# Altium schematic sheet vape.SchDoc
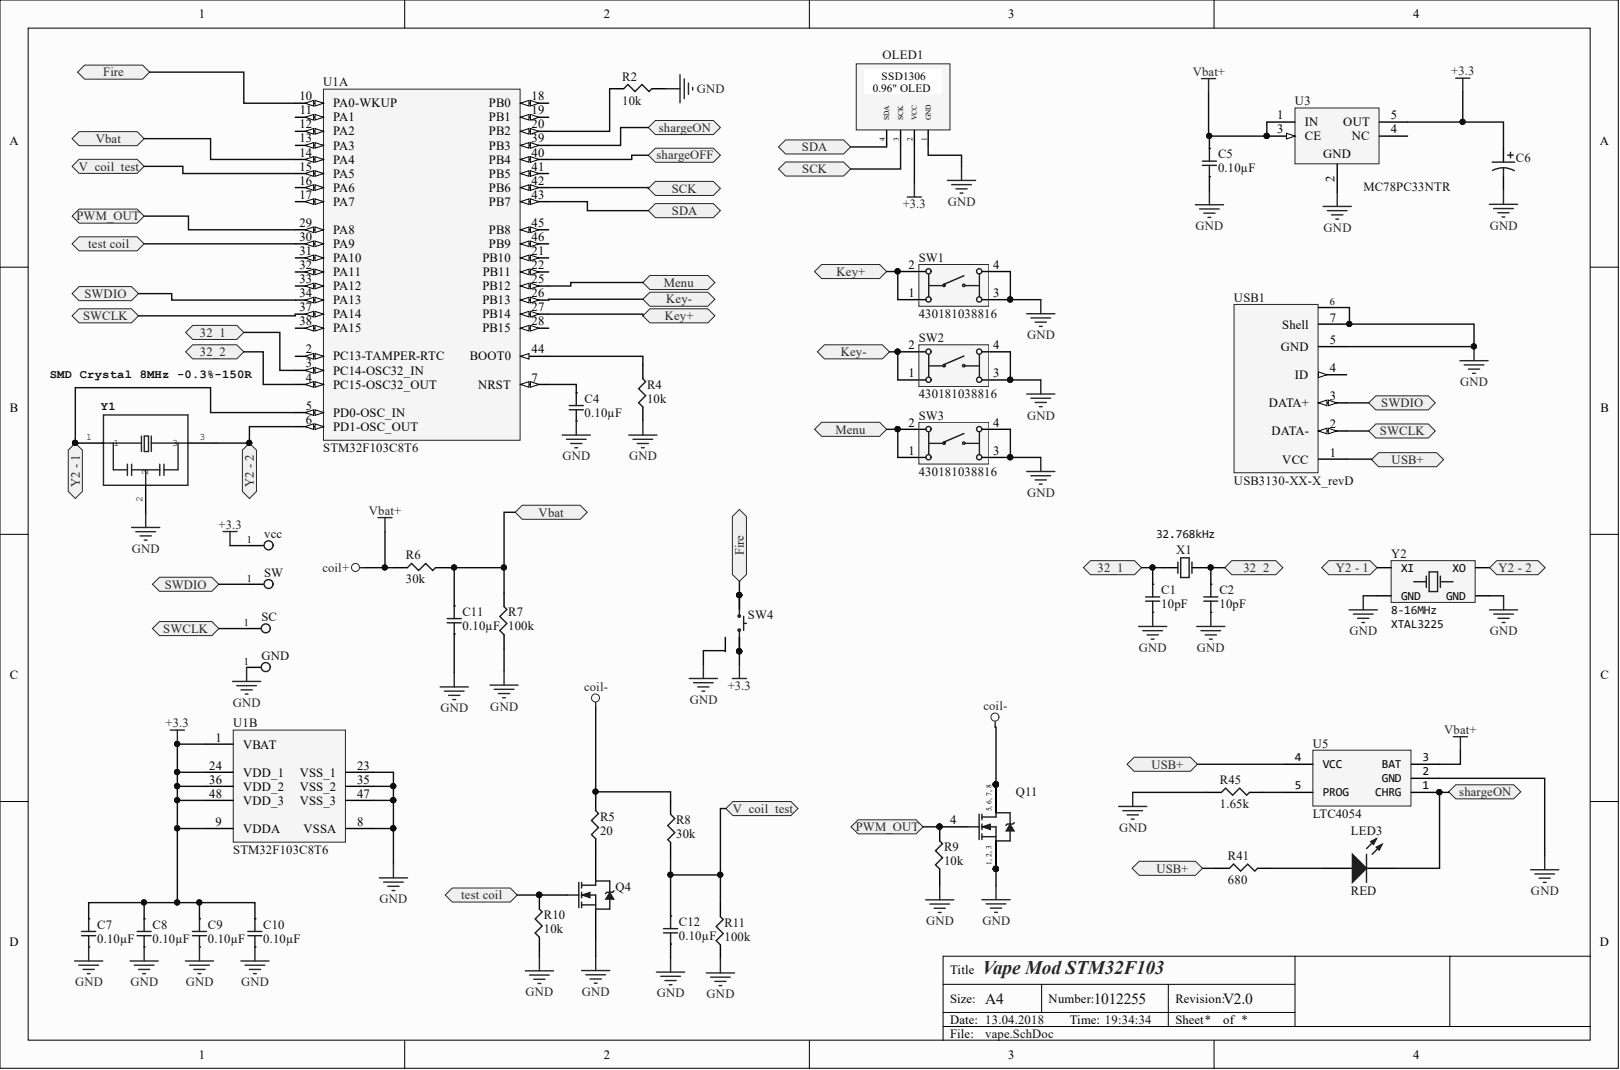
[diagram p0: vape.SchDoc]

## Parts
C1  10pF  [SMD-0402C]
C2  10pF  [SMD-0402C]
C4  0.10µF  [SMD-0805C]
C5  0.10µF  [SMD-0805C]
C6  49BC105B010M  [49BC-B]  (value not drawn)
C7  0.10µF  [SMD-0805C]
C8  0.10µF  [SMD-0805C]
C9  0.10µF  [SMD-0805C]
C10  0.10µF  [SMD-0805C]
C11  0.10µF  [SMD-0805C]
C12  0.10µF  [SMD-0805C]
GND  P2  [Pin]  (value not drawn)
LED3  RED  [0805_A]
OLED1  OLED  [SSD1306]
Q4  IRLML2803  [SOT-23_N]  (value not drawn)
Q11  IRF7413  [SO-8_N]  (value not drawn)
R2  10k  [SMD-0603-RES]
R4  10k  [SMD-0603-RES]
R5  20  [SMD-1206-RES]
R6  30k  [SMD-0603-RES]
R7  100k  [SMD-0603-RES]
R8  30k  [SMD-0603-RES]
R9  10k  [SMD-0603-RES]
R10  10k  [SMD-0603-RES]
R11  100k  [SMD-0603-RES]
R41  680  [SMD-0603-RES]
R45  1.65k  [SMD-0603-RES]
SC  P2  [Pin]  (value not drawn)
SW  P1  [Pin]  (value not drawn)
SW1  430181038816  [430181038816]
SW2  430181038816  [430181038816]
SW3  430181038816  [430181038816]
SW4  SPST-NO  [TE FSM1LPATR]  (value not drawn)
U1  STM32F103C8T6  [STM-LQFP48_N]  (parts A,B)
U3  MC78PC33NTR  [1212-01]
U5  LTC4054  [SOT23-5]
USB1  USB3130-XX-X_revD  [USB3130-XX-X_revD]
vcc  P2  [Pin]  (value not drawn)
X1  32.768kHz  [XT_3215_2]
Y1  SMD Crystal 8MHz -0.3%-150R  [OSC_CSTCE8M00G55-R0]
Y2  8-16MHz  Type: XTAL3225  [XT_3225_4]

## Ports
32_1, 32_2, FIRE, KEY+, KEY-, MENU, PWM_OUT, SCK, SDA, SHARGEOFF, SHARGEON, SWCLK, SWDIO, TEST COIL, USB+, V_COIL_TEST, VBAT, Y2 - 1, Y2 - 2

## Nets
+3.3: C6.1 C7.1 C8.1 C9.1 C10.1 OLED1.2(VCC) SW4.3 SW4.4 U1.1(VBAT) U1.9(VDDA) U1.24(VDD_1) U1.36(VDD_2) U1.48(VDD_3) U3.5(OUT) vcc.1
COIL+: C5.1 R6.1 U3.1(IN) U3.3(CE) U5.3(BAT)
COIL-: Q11.5 Q11.6 Q11.7 Q11.8 R5.2 R8.1
GND: C1.2 C2.2 C4.2 C5.2 C6.2 C7.2 C8.2 C9.2 C10.2 C11.2 C12.2 GND.1 OLED1.1(GND) Q4.2 Q11.1 Q11.2 Q11.3 R2.2 R4.1 R7.1 R9.1 R10.1 R11.1 R45.1 SW1.3 SW1.4 SW2.3 SW2.4 SW3.3 SW3.4 SW4.5 U1.8(VSSA) U1.23(VSS_1) U1.35(VSS_2) U1.47(VSS_3) U3.2 U5.2(GND) USB1.5(GND) USB1.6 USB1.7(Shell) Y1.2 Y2.2(GND) Y2.4(GND)
32_1: C1.1 U1.3(PC14-OSC32_IN) X1.1 ; port 32_1
32_2: C2.1 U1.4(PC15-OSC32_OUT) X1.2 ; port 32_2
PWM_OUT: Q11.4 R9.2 U1.29(PA8) ; port PWM_OUT
SCK: OLED1.3(SCK) U1.42(PB6) ; port SCK
SDA: OLED1.4(SDA) U1.43(PB7) ; port SDA
SWCLK: SC.1 U1.37(PA14) USB1.2(DATA-) ; port SWCLK
SWDIO: SW.1 U1.34(PA13) USB1.3(DATA+) ; port SWDIO
USB+: R41.1 U5.4(VCC) USB1.1(VCC) ; port USB+
Y2 - 1: U1.5(PD0-OSC_IN) Y1.1 Y2.1(XI) ; port Y2 - 1
Y2 - 2: U1.6(PD1-OSC_OUT) Y1.3 Y2.3(XO) ; port Y2 - 2
NetC4_1: C4.1 U1.7(NRST)
NetC11_1: C11.1 R6.2 R7.2 U1.14(PA4) ; port VBAT
NetC12_1: C12.1 R8.2 R11.2 U1.15(PA5) ; port V_COIL_TEST
NetLED3_1: LED3.1 U1.39(PB3) U5.1(CHRG) ; port SHARGEON
NetLED3_2: LED3.2 R41.2
NetQ4_1: Q4.1 R10.2 U1.30(PA9) ; port TEST COIL
NetQ4_3: Q4.3 R5.1
NetR2_1: R2.1 U1.20(PB2)
NetR4_2: R4.2 U1.44(BOOT0)
NetR45_2: R45.2 U5.5(PROG)
NetSW1_1: SW1.1 SW1.2 U1.27(PB14)
NetSW2_1: SW2.1 SW2.2 U1.26(PB13)
NetSW3_1: SW3.1 SW3.2 U1.25(PB12) ; port MENU
NetSW4_1: SW4.1 SW4.2 U1.10(PA0-WKUP) ; port FIRE
NetU1_40: U1.40(PB4) ; port SHARGEOFF

Not connected: U1 2(PC13-TAMPER-RTC) 11(PA1) 12(PA2) 13(PA3) 16(PA6) 17(PA7) 18(PB0) 19(PB1) 21(PB10) 22(PB11) 28(PB15) 31(PA10) 32(PA11) 33(PA12) 38(PA15) 41(PB5) 45(PB8) 46(PB9); U3 4(NC); USB1 4(ID)

## Library data of the parts
C1 C2 = GCM1555C1H100JA16D: CAP CER 10PF 50V NP0 0402; Manufacturer: Murata Electronics North America; @ qty: 0; Minimum Quantity: 1; Temperature Coefficient: C0G, NP0; Tolerance: ±5%; Unit Price (USD): 0.1; Applications: Automotive; Component Kind: Standard; Datasheets: http://www.murata.com/~/media/webrenewal/support/library/catalog/products/capacitor/mlcc/c03e.ashx; Digi-Key Part Number: 490-10668-1-ND; Factory Stock: 0; Features: -; Height - Seated (Max): -; Image: //media.digikey.com/Renders/Murata%20Renders/ZRB-SERIES-0402.jpg; Lead Spacing: -; Lead Style: -; Library Name: capacitor_murata_0402.IntLib; Mounting Type: Surface Mount, MLCC; Operating Temperature: -55°C ~ 125°C; Package / Case: 0402 (1005 Metric); Packaging: Cut Tape (CT); Part Number: GCM1555C1H100JA16D; Part Status: Active; Quantity Available: 966; Ratings: AEC-Q200; Series: GCM; Size / Dimension: 0.039" L x 0.020" W (1.00mm x 0.50mm); Thickness (Max): 0.022" (0.55mm); Value: 10pF; Voltage: 50V
C4 C7-C12 = GCD21BR71H104KA01L: CAP CER 0.1UF 50V X7R 0805; Manufacturer: Murata Electronics North America; @ qty: 0; Minimum Quantity: 1; Tolerance: ±10%; Unit Price (USD): 0.24; Applications: Automotive; Component Kind: Standard; Datasheets: http://www.murata.com/~/media/webrenewal/support/library/catalog/products/capacitor/mlcc/c03e.ashx; Digi-Key Part Number: 490-11955-1-ND; Factory Stock: 0; Features: -; Height - Seated (Max): -; Image: //media.digikey.com/Renders/Murata%20Renders/0805%28GxM6%29.jpg; Lead Spacing: -; Lead Style: -; Library Name: capacitor_murata_0805.IntLib; Mounting Type: Surface Mount, MLCC; Operating Temperature: -55°C ~ 125°C; Package / Case: 0805 (2012 Metric); Packaging: Cut Tape (CT); Part Number: GCD21BR71H104KA01L; Part Status: Active; Quantity Available: 157; Ratings: AEC-Q200; Series: GCD; Size / Dimension: 0.079" L x 0.049" W (2.00mm x 1.25mm); Temperature Coefficient: X7R; Thickness (Max): 0.049" (1.25mm); Value: 0.10µF; Voltage: 50V
C5 = GCM21BR91H104KA37L: CAP CER 0.1UF 50V X8R 0805; Manufacturer: Murata Electronics North America; @ qty: 0; Minimum Quantity: 1; Tolerance: ±10%; Unit Price (USD): 0.3; Applications: Automotive; Component Kind: Standard; Datasheets: http://www.murata.com/~/media/webrenewal/support/library/catalog/products/capacitor/mlcc/c03e.ashx; Digi-Key Part Number: 490-11165-1-ND; Factory Stock: 0; Features: -; Height - Seated (Max): -; Image: //media.digikey.com/photos/Murata%20Photos/NCP,GCM%20SERIES%200805.jpg; Lead Spacing: -; Lead Style: -; Library Name: capacitor_murata_0805.IntLib; Mounting Type: Surface Mount, MLCC; Operating Temperature: -55°C ~ 150°C; Package / Case: 0805 (2012 Metric); Packaging: Cut Tape (CT); Part Number: GCM21BR91H104KA37L; Part Status: Active; Quantity Available: 8905; Ratings: AEC-Q200; Series: GCM; Size / Dimension: 0.079" L x 0.049" W (2.00mm x 1.25mm); Temperature Coefficient: X8R; Thickness (Max): 0.055" (1.40mm); Value: 0.10µF; Voltage: 50V
C6 = 49BC105B010M: Conformal Coated Solid Tantalum Chip Capacitor: 1.0uF, 20% Tolerance, 10 WVDC @ -55°C to +85°C, Surge = 13V; Note: See Data Sheet for full range of components; Component Kind: Standard; ComponentLink1Description: Manufacturer Link; ComponentLink1URL: http://www.vishay.com/; DatasheetDocument: 05-Jul-2002; PackageReference: 49BC-B; Published: 19-Mar-2004; Simulation: CAP; Value: 1.0uF; Library Name: Vishay Sprague Tantalum Chip Capacitor.IntLib; Publisher: Altium Limited
GND SC SW vcc = Pin: Component Kind: Standard; Library Name: Schlib1.SchLib
LED3 = WE-LED_K1A2-2: SMD mono-color Chip LED, WL-SMCW, Blue; PartNumber: 150060BS75000; Color: Blue; Component Kind: Standard; ComponentLink1Description: Manufacturer URL; ComponentLink1URL: http://www.we-online.com; ComponentLink2Description: Datasheet; ComponentLink2URL: http://katalog.we-online.com/led/datasheet/150060BS75000.pdf; PackageReference: 0603_A; Library Name: WL-SMCW SMD chip LED top view mono-color waterclear.IntLib
OLED1 = SSD1306: Component Kind: Standard; Library Name: Schlib1.SchLib
Q4 = IRLML2803: HEXFET Power MOSFET; Component Kind: Standard; ComponentLink1Description: Manufacturer Link; ComponentLink1URL: http://www.irf.com/; ComponentLink2Description: Datasheet; ComponentLink2URL: http://www.irf.com/product-info/datasheets/data/irlml2803.pdf; DatasheetVersion: Aug-1997; LatestRevisionDate: 20-Nov-2009; LatestRevisionNote: IPC-7351 Footprint Added.; Library Name: IR Discrete MOSFET - Power.SchLib; PackageDescription: 3-Pin SOT-23 Package 0.95 mm Pitch, 2.3 mm Lead Span; PackageReference: SOT-23; Published: 8-Jun-2000; Simulation: IRLML2803; Publisher: Altium Limited
Q11 = IRF7413: HEXFET Power MOSFET; Code_IPC: SOIC127P600-8; Code_JEDEC: MS-012-AA; Component Kind: Standard; ComponentLink1Description: Manufacturer Link; ComponentLink1URL: http://www.irf.com/; ComponentLink2Description: Datasheet; ComponentLink2URL: http://www.irf.com/product-info/datasheets/data/irf7413.pdf; DatasheetVersion: Aug-1997; LatestRevisionDate: 13-Apr-2006; LatestRevisionNote: IPC-7351 Footprint Added.; Library Name: IR Discrete MOSFET - Power.SchLib; PackageDescription: 8-Pin Plastic Small Outline Integrated Circuit 1.27 mm Pitch; PackageReference: SO-8; PackageVersion: Feb-2001; Published: 8-Jun-2000; Simulation: IRF7413; Publisher: Altium Limited
R2 R4 R6-R11 = ERJ-3EKF1002V: RES SMD 10K OHM 1% 1/10W 0603; Manufacturer: Panasonic Electronic Components; @ qty: 1500; Factory Stock: 0; Minimum Quantity: 1; Power (Watts): 0.1W, 1/10W; Temperature Coefficient: ±100ppm/°C; Unit Price (USD): 0.00379; Component Kind: Standard; Composition: Thick Film; Datasheets: http://industrial.panasonic.com/www-cgi/jvcr13pz.cgi?E+PZ+3+AOA0002+ERJ3EKF1002V+7+WW; Digi-Key Part Number: P10.0KHCT-ND; Failure Rate: -; Features: Automotive AEC-Q200; Height - Seated (Max): 0.022" (0.55mm); Image: //media.digikey.com/Photos/Panasonic%20Photos/MFG_ERJ-P6W%20Series.jpg; Library Name: Panasonic_ERJ_0603.IntLib; Number of Terminations: 2; Operating Temperature: -55°C ~ 155°C; Package / Case: 0603 (1608 Metric); Packaging: Cut Tape (CT); Part Number: ERJ-3EKF1002V; Part Status: Active; Quantity Available: 6703141; Series: ERJ; Size / Dimension: 0.063" L x 0.031" W (1.60mm x 0.80mm); Supplier Device Package: 0603; Tolerance: ±1%
  R2 R4 R9 R10: Value: 10k
  R6 R8: Value: 30k
  R7 R11: Value: 100k
R5 = ERJ-8ENF20R0V: RES SMD 20 OHM 1% 1/4W 1206; Manufacturer: Panasonic Electronic Components; @ qty: 1500; Factory Stock: 0; Minimum Quantity: 1; Power (Watts): 0.25W, 1/4W; Temperature Coefficient: ±100ppm/°C; Unit Price (USD): 0.00933; Component Kind: Standard; Composition: Thick Film; Datasheets: http://industrial.panasonic.com/www-cgi/jvcr13pz.cgi?E+PZ+3+AOA0002+ERJ8ENF20R0V+7+WW; Digi-Key Part Number: P20.0FCT-ND; Failure Rate: -; Features: Automotive AEC-Q200; Height - Seated (Max): 0.028" (0.70mm); Image: //media.digikey.com/Renders/Panasonic%20Renders/ERJ-1206%20Pkg.jpg; Library Name: Panasonic_ERJ_1206.IntLib; Number of Terminations: 2; Operating Temperature: -55°C ~ 155°C; Package / Case: 1206 (3216 Metric); Packaging: Cut Tape (CT); Part Number: ERJ-8ENF20R0V; Part Status: Active; Quantity Available: 622513; Series: ERJ; Size / Dimension: 0.126" L x 0.063" W (3.20mm x 1.60mm); Supplier Device Package: 1206; Tolerance: ±1%; Value: 20
R41 = ERJ-3EKF6800V: RES SMD 680 OHM 1% 1/10W 0603; Manufacturer: Panasonic Electronic Components; @ qty: 1500; Factory Stock: 0; Minimum Quantity: 1; Power (Watts): 0.1W, 1/10W; Temperature Coefficient: ±100ppm/°C; Unit Price (USD): 0.00379; Component Kind: Standard; Composition: Thick Film; Datasheets: http://industrial.panasonic.com/www-cgi/jvcr13pz.cgi?E+PZ+3+AOA0002+ERJ3EKF6800V+7+WW; Digi-Key Part Number: P680HCT-ND; Failure Rate: -; Features: Automotive AEC-Q200; Height - Seated (Max): 0.022" (0.55mm); Image: //media.digikey.com/Photos/Panasonic%20Photos/MFG_ERJ-P6W%20Series.jpg; Library Name: Panasonic_ERJ_0603.IntLib; Number of Terminations: 2; Operating Temperature: -55°C ~ 155°C; Package / Case: 0603 (1608 Metric); Packaging: Cut Tape (CT); Part Number: ERJ-3EKF6800V; Part Status: Active; Quantity Available: 89165; Series: ERJ; Size / Dimension: 0.063" L x 0.031" W (1.60mm x 0.80mm); Supplier Device Package: 0603; Tolerance: ±1%; Value: 680
R45 = ERJ-3EKF1651V: RES SMD 1.65K OHM 1% 1/10W 0603; Manufacturer: Panasonic Electronic Components; @ qty: 1500; Factory Stock: 0; Minimum Quantity: 1; Power (Watts): 0.1W, 1/10W; Temperature Coefficient: ±100ppm/°C; Unit Price (USD): 0.00379; Component Kind: Standard; Composition: Thick Film; Datasheets: http://industrial.panasonic.com/www-cgi/jvcr13pz.cgi?E+PZ+3+AOA0002+ERJ3EKF1651V+7+WW; Digi-Key Part Number: P1.65KHCT-ND; Failure Rate: -; Features: Automotive AEC-Q200; Height - Seated (Max): 0.022" (0.55mm); Image: //media.digikey.com/Photos/Panasonic%20Photos/MFG_ERJ-P6W%20Series.jpg; Library Name: Panasonic_ERJ_0603.IntLib; Number of Terminations: 2; Operating Temperature: -55°C ~ 155°C; Package / Case: 0603 (1608 Metric); Packaging: Cut Tape (CT); Part Number: ERJ-3EKF1651V; Part Status: Active; Quantity Available: 32345; Series: ERJ; Size / Dimension: 0.063" L x 0.031" W (1.60mm x 0.80mm); Supplier Device Package: 0603; Tolerance: ±1%; Value: 1.65k
SW1-SW3 = WE-TACT-SW-4_A: WS-TASV SMD Tact Switch 4.5X4.5 mm; NoPins: 04; Component Kind: Standard; ComponentLink1Description: Manufacturer URL; ComponentLink1URL: http://www.we-online.com; ComponentLink2Description: Datasheet; ComponentLink2URL: http://katalog.we-online.de/em/datasheet/430181038816.pdf; Library Name: WS-TASV SMD Tact Switch 4.5X4.5 mm.IntLib; PackageReference: 430181038816; PartNumber: 430181038816
SW4 = SPST-NO 5 PIN: Component Kind: Standard; Library Name: Button.SchLib
U1 = MC-ARM-STM32F103X8_B-SQ48: ARM Cortex-M3 32-bit MCU, 64 KB Flash, 20 KB Internal RAM, 37 I/Os, 48-pin LQFP, -40 to 85 degC, Tray; A/D Converter: 2x12-bit (10 Channel); FLASH Size (Prog) (kB): 64; I/Os (High Current): 37; Internal RAM Size (kB): 20; Operating Frequency(F) (Processor speed) (MHz): 72; Supply Voltage(Vcc) min (V): 2; Component Kind: Standard; ComponentLink1Description: Manufacturer URL; ComponentLink1URL: http://www.st.com/web/en/home.html; ComponentLink2Description: Datasheet; ComponentLink2URL: http://www.st.com/st-web-ui/static/active/en/resource/technical/document/datasheet/CD00161566.pdf; ComponentLink3Description: Package Specification; ComponentLink3URL: http://www.st.com/st-web-ui/static/active/en/resource/technical/document/datasheet/DM00058181.pdf; Core: ARM Cortex-M3; DatasheetVersion: Rev. 16; Library Name: STMicroelectronics STM32 F1.SchLib; Mounting Technology: Surface Mount; PackageDescription: 48-Pin Low Profile Quad Flat Package, 7 x 7 mm Body, 0.5 mm Pitch; PackageReference: LQFP48; PackageVersion: Rev. 6, 06/2013; Packing: Tray; PartNumber: STM32F103C8T6; Supplier 1: Digi-Key; Supplier 2: Farnell; Supplier 3: Newark; Supplier 4: Newark; Supplier 5: Mouser; Supplier Part Number 1: 497-6063-ND; Supplier Part Number 2: 1447637; Supplier Part Number 3: 59M2182; Supplier Part Number 4: 57P1222; Supplier Part Number 5: 511-STM32F103C8T6
U3 = MC78PC33NTR: Low Noise 150mA Low Drop Out (LDO) Linear Voltage Regulator; Component Kind: Standard; ComponentLink1Description: Manufacturer Link; ComponentLink1URL: http://www.onsemi.com/site/products/taxonomy/0,4438,503,00.html; ComponentLink2Description: Datasheet; ComponentLink2URL: http://www.onsemi.com/pub/Collateral/MC78PC00-D.PDF; DatasheetVersion: Oct-1999; Library Name: ON Semi Power Mgt Voltage Regulator.IntLib; PackageDescription: SOT-23/SC-74A; 5 Leads; Body 3 x 3.1 mm, inc. leads (LxW max); PackageReference: 1212-01; PackageVersion: Jul-1999; Published: 15-Apr-2002; Publisher: Altium Limited
U5 = LTC4054: Charge; Component Kind: Standard; Library Name: SCH_IntegratedCircuit.SchLib
USB1 = USB3130-XX-X_revD: Micro USB Receptacle, Type B, Through-Hole, Vertical, with 1.5mm Shell Stakes; Component Kind: Standard; Library Name: USB3130-30-X_revD.IntLib
X1 = XTAL_32.768k: Crystal Oscillator; Component Kind: Standard; Library Name: SCH_Oscillator.SchLib; Value: 32.768kHz
Y1 = CSTCE8M00G55-R0: MF: Murata; Availability: Good; Component Kind: Standard; Library Name: CSTCE8M00G55-R0.SCHLIB; MP: CSTCE8M00G55-R0; Package: SMD-3 Murata; Price: 0.28 USD
Y2 = XTAL_3225_4P: Crystal Oscillator; Component Kind: Standard; Library Name: SCH_Oscillator.SchLib; Value: 8-16MHz
